# Altium schematic sheet Sch8 - Isoladores dos Rotores.SchDoc("Sch8 - Isoladores dos Rotores")
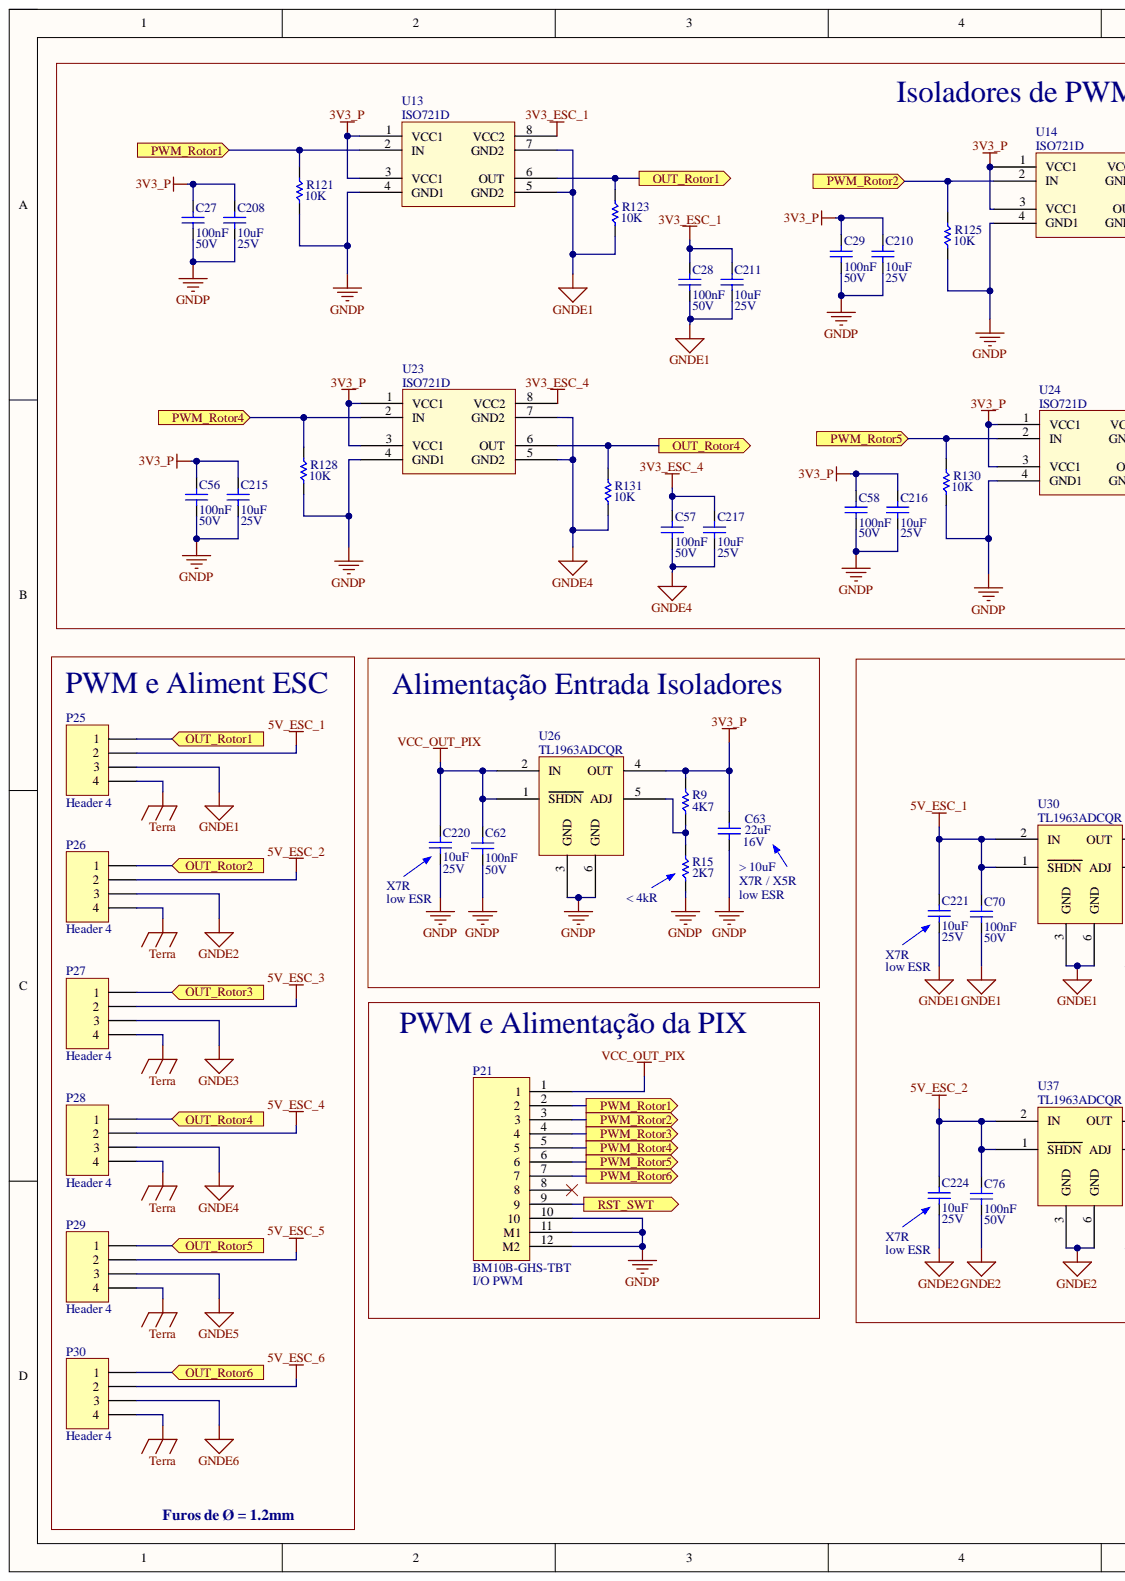
[diagram p0: Sch8 - Isoladores dos Rotores.SchDoc, left]
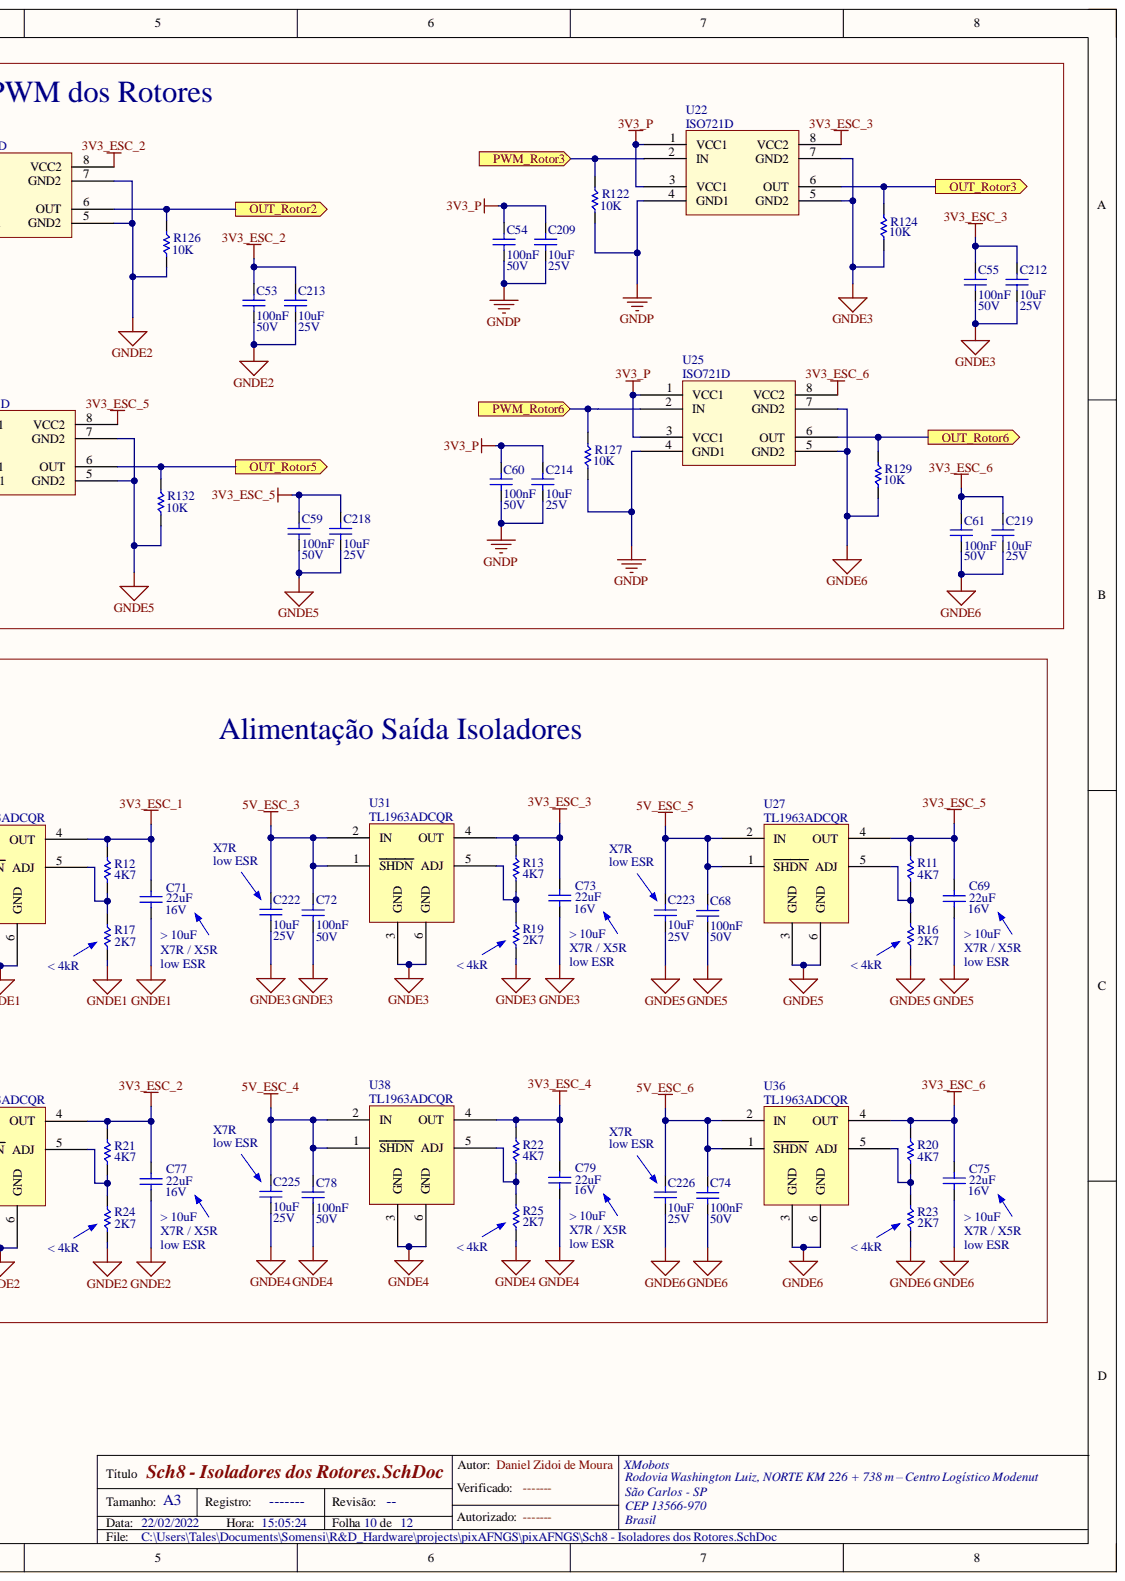
[diagram p1: Sch8 - Isoladores dos Rotores.SchDoc, right]

## Parts
C27  Capacitance: 100nF  Voltage: 50V  [0603]  (hidden comment: CL10B104MB8NNNC)
C28  Capacitance: 100nF  Voltage: 50V  [0603]  (hidden comment: CL10B104MB8NNNC)
C29  Capacitance: 100nF  Voltage: 50V  [0603]  (hidden comment: CL10B104MB8NNNC)
C53  Capacitance: 100nF  Voltage: 50V  [0603]  (hidden comment: CL10B104MB8NNNC)
C54  Capacitance: 100nF  Voltage: 50V  [0603]  (hidden comment: CL10B104MB8NNNC)
C55  Capacitance: 100nF  Voltage: 50V  [0603]  (hidden comment: CL10B104MB8NNNC)
C56  Capacitance: 100nF  Voltage: 50V  [0603]  (hidden comment: CL10B104MB8NNNC)
C57  Capacitance: 100nF  Voltage: 50V  [0603]  (hidden comment: CL10B104MB8NNNC)
C58  Capacitance: 100nF  Voltage: 50V  [0603]  (hidden comment: CL10B104MB8NNNC)
C59  Capacitance: 100nF  Voltage: 50V  [0603]  (hidden comment: CL10B104MB8NNNC)
C60  Capacitance: 100nF  Voltage: 50V  [0603]  (hidden comment: CL10B104MB8NNNC)
C61  Capacitance: 100nF  Voltage: 50V  [0603]  (hidden comment: CL10B104MB8NNNC)
C62  Capacitance: 100nF  Voltage: 50V  [0603]  (hidden comment: CL10B104MB8NNNC)
C63  Capacitance: 22uF  Voltage: 16V  [SMD-CER-1206]  (hidden comment: CL31A226KOCLNNC)
C68  Capacitance: 100nF  Voltage: 50V  [0603]  (hidden comment: CL10B104MB8NNNC)
C69  Capacitance: 22uF  Voltage: 16V  [SMD-CER-1206]  (hidden comment: CL31A226KOCLNNC)
C70  Capacitance: 100nF  Voltage: 50V  [0603]  (hidden comment: CL10B104MB8NNNC)
C71  Capacitance: 22uF  Voltage: 16V  [SMD-CER-1206]  (hidden comment: CL31A226KOCLNNC)
C72  Capacitance: 100nF  Voltage: 50V  [0603]  (hidden comment: CL10B104MB8NNNC)
C73  Capacitance: 22uF  Voltage: 16V  [SMD-CER-1206]  (hidden comment: CL31A226KOCLNNC)
C74  Capacitance: 100nF  Voltage: 50V  [0603]  (hidden comment: CL10B104MB8NNNC)
C75  Capacitance: 22uF  Voltage: 16V  [SMD-CER-1206]  (hidden comment: CL31A226KOCLNNC)
C76  Capacitance: 100nF  Voltage: 50V  [0603]  (hidden comment: CL10B104MB8NNNC)
C77  Capacitance: 22uF  Voltage: 16V  [SMD-CER-1206]  (hidden comment: CL31A226KOCLNNC)
C78  Capacitance: 100nF  Voltage: 50V  [0603]  (hidden comment: CL10B104MB8NNNC)
C79  Capacitance: 22uF  Voltage: 16V  [SMD-CER-1206]  (hidden comment: CL31A226KOCLNNC)
C208  Capacitance: 10uF  Voltage: 25V  [CAP-XMO-0603]  (hidden comment: GRM188R61E106KA73J)
C209  Capacitance: 10uF  Voltage: 25V  [CAP-XMO-0603]  (hidden comment: GRM188R61E106KA73J)
C210  Capacitance: 10uF  Voltage: 25V  [CAP-XMO-0603]  (hidden comment: GRM188R61E106KA73J)
C211  Capacitance: 10uF  Voltage: 25V  [CAP-XMO-0603]  (hidden comment: GRM188R61E106KA73J)
C212  Capacitance: 10uF  Voltage: 25V  [CAP-XMO-0603]  (hidden comment: GRM188R61E106KA73J)
C213  Capacitance: 10uF  Voltage: 25V  [CAP-XMO-0603]  (hidden comment: GRM188R61E106KA73J)
C214  Capacitance: 10uF  Voltage: 25V  [CAP-XMO-0603]  (hidden comment: GRM188R61E106KA73J)
C215  Capacitance: 10uF  Voltage: 25V  [CAP-XMO-0603]  (hidden comment: GRM188R61E106KA73J)
C216  Capacitance: 10uF  Voltage: 25V  [CAP-XMO-0603]  (hidden comment: GRM188R61E106KA73J)
C217  Capacitance: 10uF  Voltage: 25V  [CAP-XMO-0603]  (hidden comment: GRM188R61E106KA73J)
C218  Capacitance: 10uF  Voltage: 25V  [CAP-XMO-0603]  (hidden comment: GRM188R61E106KA73J)
C219  Capacitance: 10uF  Voltage: 25V  [CAP-XMO-0603]  (hidden comment: GRM188R61E106KA73J)
C220  Capacitance: 10uF  Voltage: 25V  [0603]  (hidden comment: GRM188R61E106KA73J)
C221  Capacitance: 10uF  Voltage: 25V  [0603]  (hidden comment: GRM188R61E106KA73J)
C222  Capacitance: 10uF  Voltage: 25V  [0603]  (hidden comment: GRM188R61E106KA73J)
C223  Capacitance: 10uF  Voltage: 25V  [0603]  (hidden comment: GRM188R61E106KA73J)
C224  Capacitance: 10uF  Voltage: 25V  [0603]  (hidden comment: GRM188R61E106KA73J)
C225  Capacitance: 10uF  Voltage: 25V  [0603]  (hidden comment: GRM188R61E106KA73J)
C226  Capacitance: 10uF  Voltage: 25V  [0603]  (hidden comment: GRM188R61E106KA73J)
P21  BM10B-GHS-TBT  [BM10B-GHS-TBT]
P25  Header 4  [HDR1X4]
P26  Header 4  [HDR1X4]
P27  Header 4  [HDR1X4]
P28  Header 4  [HDR1X4]
P29  Header 4  [HDR1X4]
P30  Header 4  [HDR1X4]
R9  Resistance: 4K7  [Res_0603]  (hidden comment: RMCF0603FT4K70)
R11  Resistance: 4K7  [Res_0603]  (hidden comment: RMCF0603FT4K70)
R12  Resistance: 4K7  [Res_0603]  (hidden comment: RMCF0603FT4K70)
R13  Resistance: 4K7  [Res_0603]  (hidden comment: RMCF0603FT4K70)
R15  Resistance: 2K7  [Res_0603]  (hidden comment: CRGCQ0603F2K7)
R16  Resistance: 2K7  [Res_0603]  (hidden comment: CRGCQ0603F2K7)
R17  Resistance: 2K7  [Res_0603]  (hidden comment: CRGCQ0603F2K7)
R19  Resistance: 2K7  [Res_0603]  (hidden comment: CRGCQ0603F2K7)
R20  Resistance: 4K7  [Res_0603]  (hidden comment: RMCF0603FT4K70)
R21  Resistance: 4K7  [Res_0603]  (hidden comment: RMCF0603FT4K70)
R22  Resistance: 4K7  [Res_0603]  (hidden comment: RMCF0603FT4K70)
R23  Resistance: 2K7  [Res_0603]  (hidden comment: CRGCQ0603F2K7)
R24  Resistance: 2K7  [Res_0603]  (hidden comment: CRGCQ0603F2K7)
R25  Resistance: 2K7  [Res_0603]  (hidden comment: CRGCQ0603F2K7)
R121  Resistance: 10K  [RES-XMO-0603]  (hidden comment: RMCF0603FT10K0)
R122  Resistance: 10K  [RES-XMO-0603]  (hidden comment: RMCF0603FT10K0)
R123  Resistance: 10K  [RES-XMO-0603]  (hidden comment: RMCF0603FT10K0)
R124  Resistance: 10K  [RES-XMO-0603]  (hidden comment: RMCF0603FT10K0)
R125  Resistance: 10K  [RES-XMO-0603]  (hidden comment: RMCF0603FT10K0)
R126  Resistance: 10K  [RES-XMO-0603]  (hidden comment: RMCF0603FT10K0)
R127  Resistance: 10K  [RES-XMO-0603]  (hidden comment: RMCF0603FT10K0)
R128  Resistance: 10K  [RES-XMO-0603]  (hidden comment: RMCF0603FT10K0)
R129  Resistance: 10K  [RES-XMO-0603]  (hidden comment: RMCF0603FT10K0)
R130  Resistance: 10K  [RES-XMO-0603]  (hidden comment: RMCF0603FT10K0)
R131  Resistance: 10K  [RES-XMO-0603]  (hidden comment: RMCF0603FT10K0)
R132  Resistance: 10K  [RES-XMO-0603]  (hidden comment: RMCF0603FT10K0)
U13  ISO721D  [SOIC-8_D-PDSO-G8]
U14  ISO721D  [SOIC-8_D-PDSO-G8]
U22  ISO721D  [SOIC-8_D-PDSO-G8]
U23  ISO721D  [SOIC-8_D-PDSO-G8]
U24  ISO721D  [SOIC-8_D-PDSO-G8]
U25  ISO721D  [SOIC-8_D-PDSO-G8]
U26  TL1963ADCQR  [SOT127_R-PDSO-G6]
U27  TL1963ADCQR  [SOT127_R-PDSO-G6]
U30  TL1963ADCQR  [SOT127_R-PDSO-G6]
U31  TL1963ADCQR  [SOT127_R-PDSO-G6]
U36  TL1963ADCQR  [SOT127_R-PDSO-G6]
U37  TL1963ADCQR  [SOT127_R-PDSO-G6]
U38  TL1963ADCQR  [SOT127_R-PDSO-G6]

## Ports
OUT_Rotor1, OUT_Rotor2, OUT_Rotor3, OUT_Rotor4, OUT_Rotor5, OUT_Rotor6, PWM_Rotor1, PWM_Rotor2, PWM_Rotor3, PWM_Rotor4, PWM_Rotor5, PWM_Rotor6, RST_SWT

## Nets
3V3_ESC_1: C28.2 C71.2 C211.2 R12.2 U13.8(VCC2) U30.4(OUT)
3V3_ESC_2: C53.2 C77.2 C213.2 R21.2 U14.8(VCC2) U37.4(OUT)
3V3_ESC_3: C55.2 C73.2 C212.2 R13.2 U22.8(VCC2) U31.4(OUT)
3V3_ESC_4: C57.2 C79.2 C217.2 R22.2 U23.8(VCC2) U38.4(OUT)
3V3_ESC_5: C59.2 C69.2 C218.2 R11.2 U24.8(VCC2) U27.4(OUT)
3V3_ESC_6: C61.2 C75.2 C219.2 R20.2 U25.8(VCC2) U36.4(OUT)
3V3_P: C27.2 C29.2 C54.2 C56.2 C58.2 C60.2 C63.2 C208.2 C209.2 C210.2 C214.2 C215.2 C216.2 R9.2 U13.1(VCC1) U13.3(VCC1) U14.1(VCC1) U14.3(VCC1) U22.1(VCC1) U22.3(VCC1) U23.1(VCC1) U23.3(VCC1) U24.1(VCC1) U24.3(VCC1) U25.1(VCC1) U25.3(VCC1) U26.4(OUT)
5V_ESC_1: C70.2 C221.2 P25.2 U30.1(SHDN) U30.2(IN)
5V_ESC_2: C76.2 C224.2 P26.2 U37.1(SHDN) U37.2(IN)
5V_ESC_3: C72.2 C222.2 P27.2 U31.1(SHDN) U31.2(IN)
5V_ESC_4: C78.2 C225.2 P28.2 U38.1(SHDN) U38.2(IN)
5V_ESC_5: C68.2 C223.2 P29.2 U27.1(SHDN) U27.2(IN)
5V_ESC_6: C74.2 C226.2 P30.2 U36.1(SHDN) U36.2(IN)
GNDE1: C28.1 C70.1 C71.1 C211.1 C221.1 P25.3 R17.1 R123.2 U13.5(GND2) U13.7(GND2) U30.3(GND) U30.6(GND)
GNDE2: C53.1 C76.1 C77.1 C213.1 C224.1 P26.3 R24.1 R126.2 U14.5(GND2) U14.7(GND2) U37.3(GND) U37.6(GND)
GNDE3: C55.1 C72.1 C73.1 C212.1 C222.1 P27.3 R19.1 R124.2 U22.5(GND2) U22.7(GND2) U31.3(GND) U31.6(GND)
GNDE4: C57.1 C78.1 C79.1 C217.1 C225.1 P28.3 R25.1 R131.2 U23.5(GND2) U23.7(GND2) U38.3(GND) U38.6(GND)
GNDE5: C59.1 C68.1 C69.1 C218.1 C223.1 P29.3 R16.1 R132.2 U24.5(GND2) U24.7(GND2) U27.3(GND) U27.6(GND)
GNDE6: C61.1 C74.1 C75.1 C219.1 C226.1 P30.3 R23.1 R129.2 U25.5(GND2) U25.7(GND2) U36.3(GND) U36.6(GND)
GNDP: C27.1 C29.1 C54.1 C56.1 C58.1 C60.1 C62.1 C63.1 C208.1 C209.1 C210.1 C214.1 C215.1 C216.1 C220.1 P21.10 P21.11(M1) P21.12(M2) R15.1 R121.2 R122.2 R125.2 R127.2 R128.2 R130.2 U13.4(GND1) U14.4(GND1) U22.4(GND1) U23.4(GND1) U24.4(GND1) U25.4(GND1) U26.3(GND) U26.6(GND)
Terra: P25.4 P26.4 P27.4 P28.4 P29.4 P30.4
VCC_OUT_PIX: C62.2 C220.2 P21.1 U26.1(SHDN) U26.2(IN)
OUT_Rotor1: P25.1 R123.1 U13.6(OUT) ; port OUT_Rotor1
OUT_Rotor2: P26.1 R126.1 U14.6(OUT) ; port OUT_Rotor2
OUT_Rotor3: P27.1 R124.1 U22.6(OUT) ; port OUT_Rotor3
OUT_Rotor4: P28.1 R131.1 U23.6(OUT) ; port OUT_Rotor4
OUT_Rotor5: P29.1 R132.1 U24.6(OUT) ; port OUT_Rotor5
OUT_Rotor6: P30.1 R129.1 U25.6(OUT) ; port OUT_Rotor6
PWM_Rotor1: P21.2 R121.1 U13.2(IN) ; port PWM_Rotor1
PWM_Rotor2: P21.3 R125.1 U14.2(IN) ; port PWM_Rotor2
PWM_Rotor3: P21.4 R122.1 U22.2(IN) ; port PWM_Rotor3
PWM_Rotor4: P21.5 R128.1 U23.2(IN) ; port PWM_Rotor4
PWM_Rotor5: P21.6 R130.1 U24.2(IN) ; port PWM_Rotor5
PWM_Rotor6: P21.7 R127.1 U25.2(IN) ; port PWM_Rotor6
RST_SWT: P21.9 ; port RST_SWT
NetR9_1: R9.1 R15.2 U26.5(ADJ)
NetR11_1: R11.1 R16.2 U27.5(ADJ)
NetR12_1: R12.1 R17.2 U30.5(ADJ)
NetR13_1: R13.1 R19.2 U31.5(ADJ)
NetR20_1: R20.1 R23.2 U36.5(ADJ)
NetR21_1: R21.1 R24.2 U37.5(ADJ)
NetR22_1: R22.1 R25.2 U38.5(ADJ)

Not connected: P21 8

## Library data of the parts
C27-C29 C53-C62 C68 C70 C72 C74 C76 C78 = C-100nF-50V-X7R-0603: Capacitor 100nF 50V X7R 0603; Component Kind: Standard; Part Number: CL10B104MB8NNNC; Library Name: xmobots_-_comp_library.IntLib
C63 C69 C71 C73 C75 C77 C79 = C-22uF-16V-X5R-1206: Capacitor 22uF 16V X5R 1206; Component Kind: Standard; Part Number: CL31A226KOCLNNC; Library Name: xmobots_-_comp_library.IntLib
C208-C226 = C-CER-10uF-25V-X5R-0603: Capacitor 10uF 25V X5R 0603; Component Kind: Standard; Part Number: GRM188R61E106KA73J; Library Name: xmobots_-_comp_library.IntLib
P21 = BM10B-GHS-TBT: JST GH 10 Vias Vertical; Component Kind: Standard; Library Name: XM_Connector.SchLib
P25-P30 = Header 4: Header, 4-Pin; Component Kind: Standard; LatestRevisionDate: 17-Jul-2002; LatestRevisionNote: Re-released for DXP Platform.; Library Name: Miscellaneous Connectors.IntLib; Signal Integrity: Connector; Publisher: Altium Limited
R9 R11-R13 R20-R22 = R-4K7-1/10W-1%-0603: Resistor 4k7 ohms 1/10W 1% 0603; Component Kind: Standard; Part Number: RMCF0603FT4K70; Power: 1/10W; Tolerance: ±1%; Library Name: xmobots_-_comp_library.IntLib
R15-R17 R19 R23-R25 = R-2K7-1/10W-1%-0603: Resistor 2k7 ohms 1/10W 1% 0603; Component Kind: Standard; Part Number: CRGCQ0603F2K7; Power: 1/10W; Tolerance: ±1%; Library Name: xmobots_-_comp_library.IntLib
R121-R132 = R-10K-1/10W-1%-0603: Resistor 10k ohms 1/10W 1% 0603; Component Kind: Standard; Part Number: RMCF0603FT10K0; Power: 1/10W; Tolerance: ±1%; Library Name: xmobots_-_comp_library.IntLib
U13 U14 U22-U25 = ISO721D: 3.3-V / 5-V HIGH-SPEED DIGITAL ISOLATORS; Component Kind: Standard; Supplier 1: Digi-Key; Supplier Part Number 1: 296-19382-ND; Library Name: XM_IC.SchLib
U26 U27 U30 U31 U36-U38 = TL1963ADCQR: 1.5-A LOW-NOISE FAST-TRANSIENT-RESPONSE LOW-DROPOUT REGULATOR; Component Kind: Standard; Supplier 1: Digi-Key; Supplier Part Number 1: 296-25119-1-ND; Library Name: XM_IC.SchLib
